# Altium schematic sheet Sheet1.SchDoc
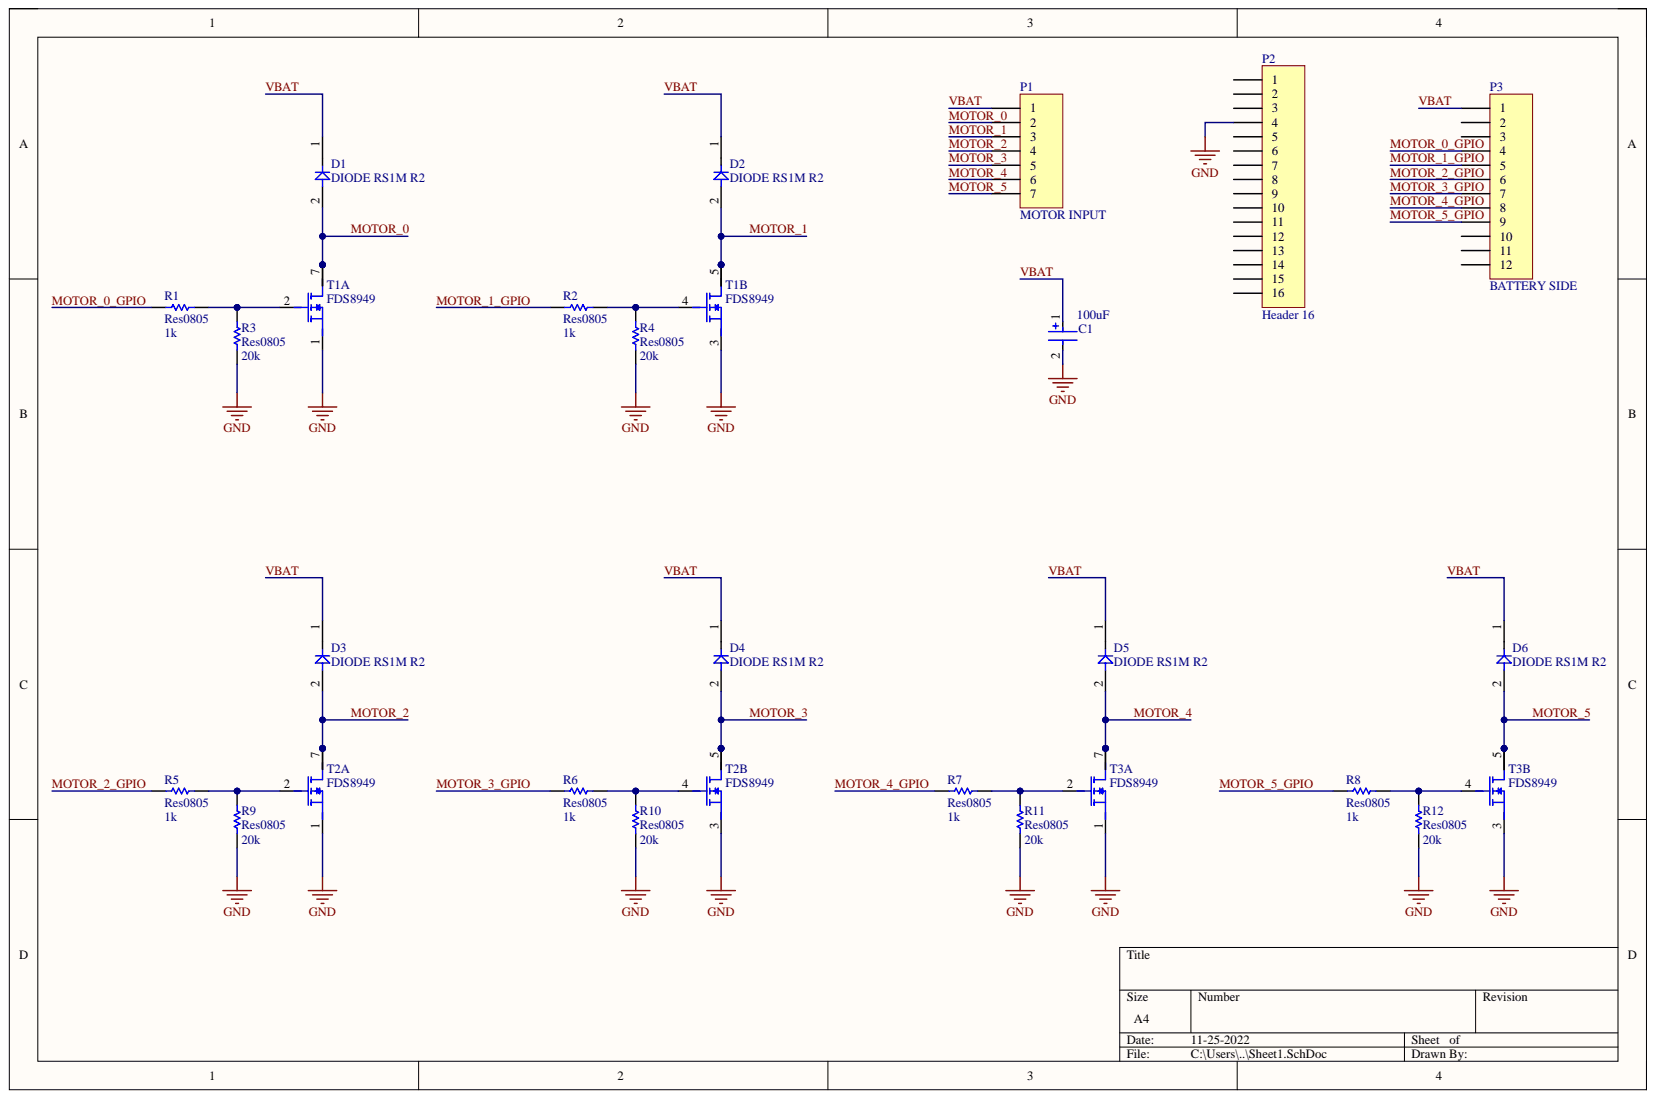
[diagram p0: Sheet1.SchDoc]

## Parts
C1  Electro capacitor  [100uF capacitor]  (value not drawn)
D1  DIODE RS1M R2  [RS1M R2]
D2  DIODE RS1M R2  [RS1M R2]
D3  DIODE RS1M R2  [RS1M R2]
D4  DIODE RS1M R2  [RS1M R2]
D5  DIODE RS1M R2  [RS1M R2]
D6  DIODE RS1M R2  [RS1M R2]
P1  MOTOR INPUT  [HDR1X7]
P2  Header 16  [HDR1X16]
P3  BATTERY SIDE  [HDR1X12]
R1  Res0805  value 1k  [Resistor 0805]
R2  Res0805  value 1k  [Resistor 0805]
R3  Res0805  value 20k  [Resistor 0805]
R4  Res0805  value 20k  [Resistor 0805]
R5  Res0805  value 1k  [Resistor 0805]
R6  Res0805  value 1k  [Resistor 0805]
R7  Res0805  value 1k  [Resistor 0805]
R8  Res0805  value 1k  [Resistor 0805]
R9  Res0805  value 20k  [Resistor 0805]
R10  Res0805  value 20k  [Resistor 0805]
R11  Res0805  value 20k  [Resistor 0805]
R12  Res0805  value 20k  [Resistor 0805]
T1  FDS8949  [FDS8949]  (parts A,B)
T2  FDS8949  [FDS8949]  (parts A,B)
T3  FDS8949  [FDS8949]  (parts A,B)

## Nets
GND: C1.2 P2.4 R3.1 R4.1 R9.1 R10.1 R11.1 R12.1 T1.1 T1.3 T2.1 T2.3 T3.1 T3.3
MOTOR_0: D1.2 P1.2 T1.7 T1.8
MOTOR_0_GPIO: P3.4 R1.1
MOTOR_1: D2.2 P1.3 T1.5 T1.6
MOTOR_1_GPIO: P3.5 R2.1
MOTOR_2: D3.2 P1.4 T2.7 T2.8
MOTOR_2_GPIO: P3.6 R5.1
MOTOR_3: D4.2 P1.5 T2.5 T2.6
MOTOR_3_GPIO: P3.7 R6.1
MOTOR_4: D5.2 P1.6 T3.7 T3.8
MOTOR_4_GPIO: P3.8 R7.1
MOTOR_5: D6.2 P1.7 T3.5 T3.6
MOTOR_5_GPIO: P3.9 R8.1
VBAT: C1.1 D1.1 D2.1 D3.1 D4.1 D5.1 D6.1 P1.1 P3.1
NetR1_2: R1.2 R3.2 T1.2
NetR2_2: R2.2 R4.2 T1.4
NetR5_2: R5.2 R9.2 T2.2
NetR6_2: R6.2 R10.2 T2.4
NetR7_2: R7.2 R11.2 T3.2
NetR8_2: R8.2 R12.2 T3.4

Not connected: P2 1 2 3 5 6 7 8 9 10 11 12 13 14 15 16; P3 2 3 10 11 12

## Library data of the parts
P1 = Header 7: Header, 7-Pin; Component Kind: Standard; LatestRevisionDate: 17-Jul-2002; LatestRevisionNote: Re-released for DXP Platform.; Library Name: Miscellaneous Connectors.IntLib; Signal Integrity: Connector; Publisher: Altium Limited
P2 = Header 16: Header, 16-Pin; Component Kind: Standard; LatestRevisionDate: 17-Jul-2002; LatestRevisionNote: Re-released for DXP Platform.; Library Name: Miscellaneous Connectors.IntLib; Signal Integrity: Connector; Publisher: Altium Limited
P3 = Header 12: Header, 12-Pin; Component Kind: Standard; LatestRevisionDate: 17-Jul-2002; LatestRevisionNote: Re-released for DXP Platform.; Library Name: Miscellaneous Connectors.IntLib; Signal Integrity: Connector; Publisher: Altium Limited
R1-R12 = Resistor 0805: Component Kind: Standard; Library Name: main_shematic_lib.SchLib
C1 = Electro capacitor: Component Kind: Standard; Library Name: main_shematic_lib.SchLib
D1-D6 = DIODE RS1M R2: Component Kind: Standard; Library Name: main_shematic_lib.SchLib
T1-T3 = FDS8949: Component Kind: Standard; Library Name: main_shematic_lib.SchLib
